# Altium schematic sheet [05] - BME680.SchDoc
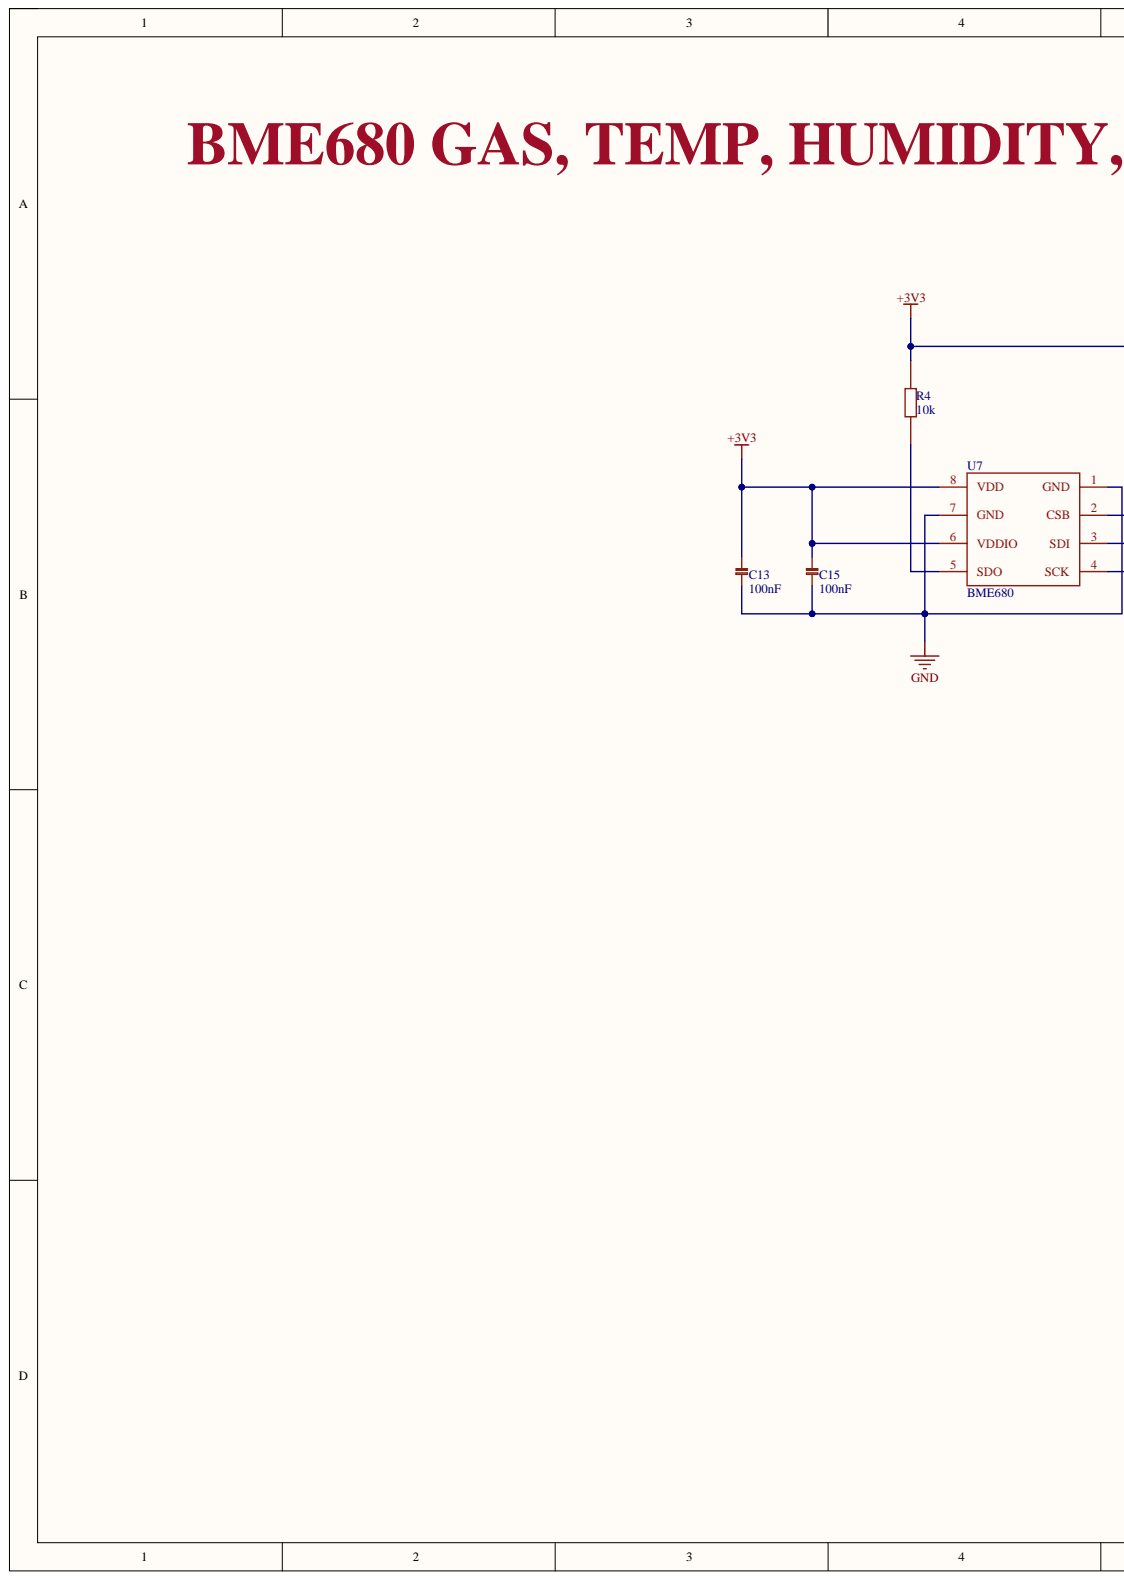
[diagram p0: [05] - BME680.SchDoc, left]
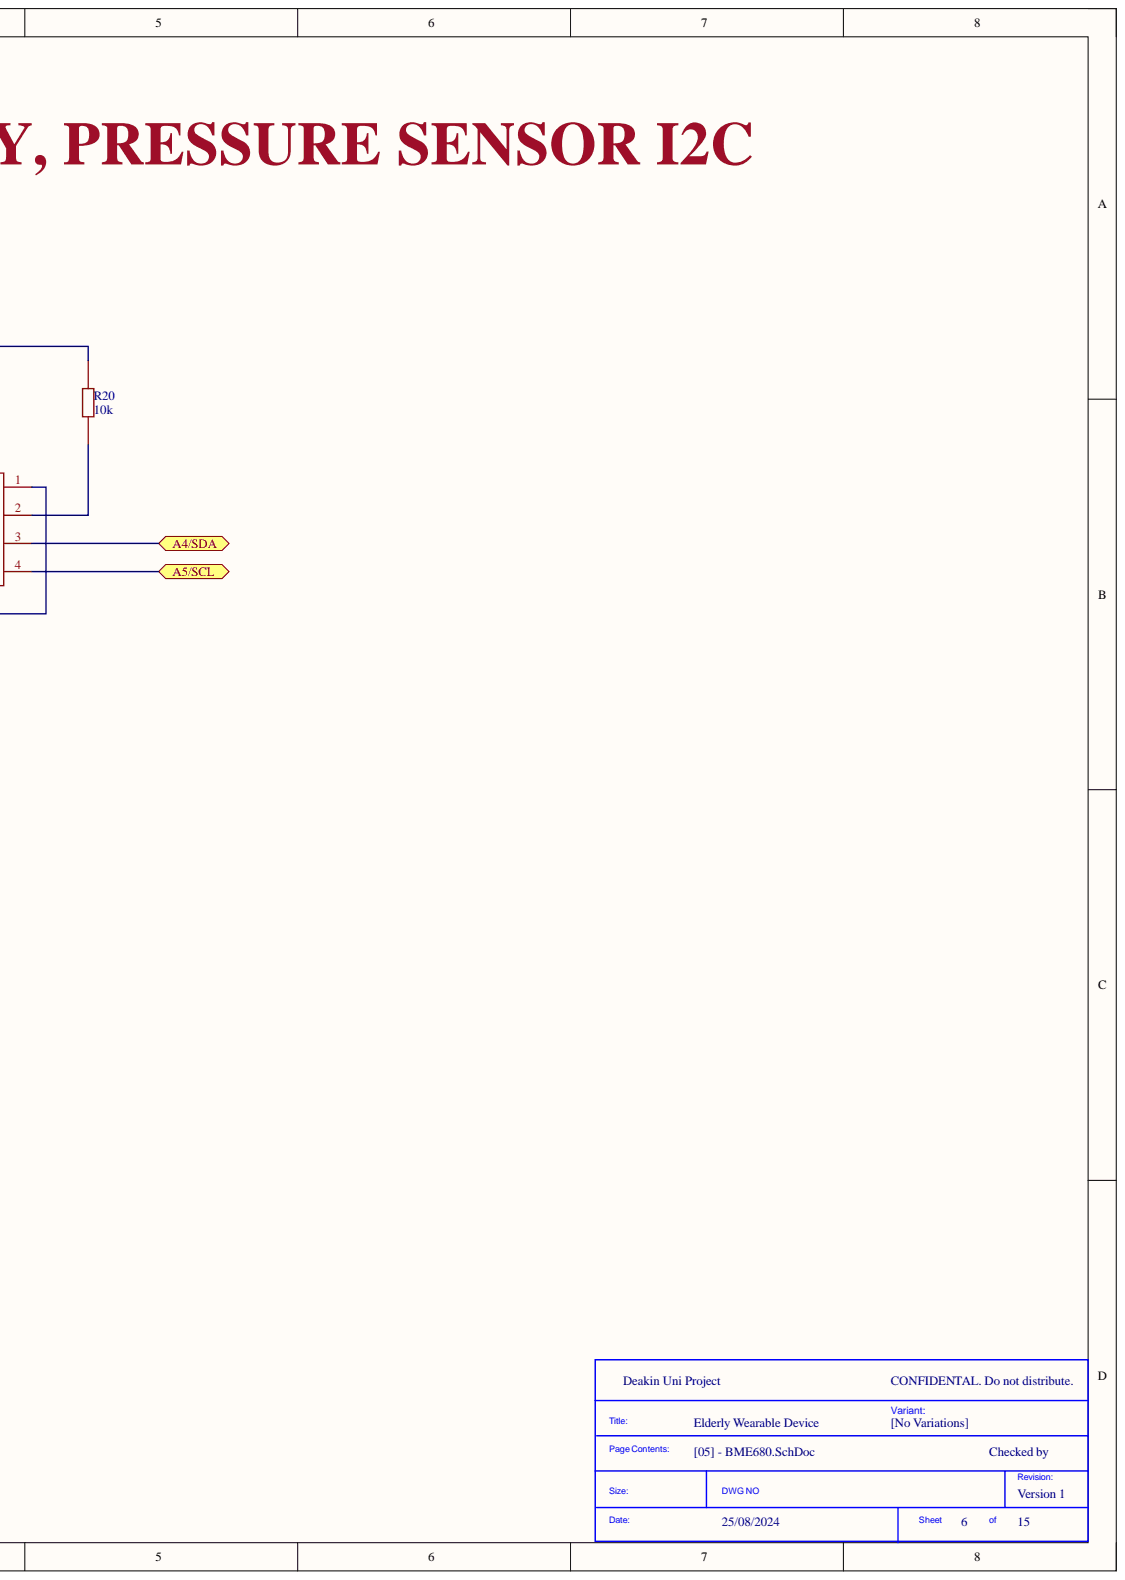
[diagram p1: [05] - BME680.SchDoc, right]

## Parts
C13  100nF  [C_0402]
C15  100nF  [C_0402]
R4  10k  [R_0603]
R20  10k  [R_0603]
U7  BME680  [BME680]

## Ports
A4/SDA, A5/SCL

## Nets
+3V3: C13.2 C15.2 R4.1 R20.1 U7.6(VDDIO) U7.8(VDD)
GND: C13.1 C15.1 U7.1(GND) U7.7(GND)
A4/SDA: U7.3(SDI) ; port A4/SDA
A5/SCL: U7.4(SCK) ; port A5/SCL
NetR4_2: R4.2 U7.5(SDO)
NetR20_2: R20.2 U7.2(CSB)

## Library data of the parts
C13 C15 = C_0402_100nF: SMD Capacitor; Manufacturer: Murata Electronics; Manufacturer Part Number: GRM155R71C104KA88J; ALL GOOD: OK_DONE; Component Kind: Standard; Package: 0402; Supplier: Digi-Key; Supplier Part Number: 490-6328-1-ND; Library Name: Elderly_Wearable_Device.SCHLIB
R4 R20 = R_0603_10k: SMD Resistor; Manufacturer: Yageo; Manufacturer Part Number: RC0603FR-0710KL; ALL GOOD: OK_DONE; Component Kind: Standard; Package: 0603; Supplier: Digi-Key; Supplier Part Number: 311-10.0KHRCT-ND; Library Name: Elderly_Wearable_Device.SCHLIB
U7 = BME680: BME680 sensor; Manufacturer: Bosch Sensortec; ALL GOOD: OK_DONE; Component Kind: Standard; Manufacturer Part Number: BME680; Package: metal-lid LGA; Supplier: Digi-Key; Supplier Part Number: 828-1077-1-ND; Library Name: Elderly_Wearable_Device.SCHLIB
